# Altium schematic sheet Khoi_Sensor.SchDoc
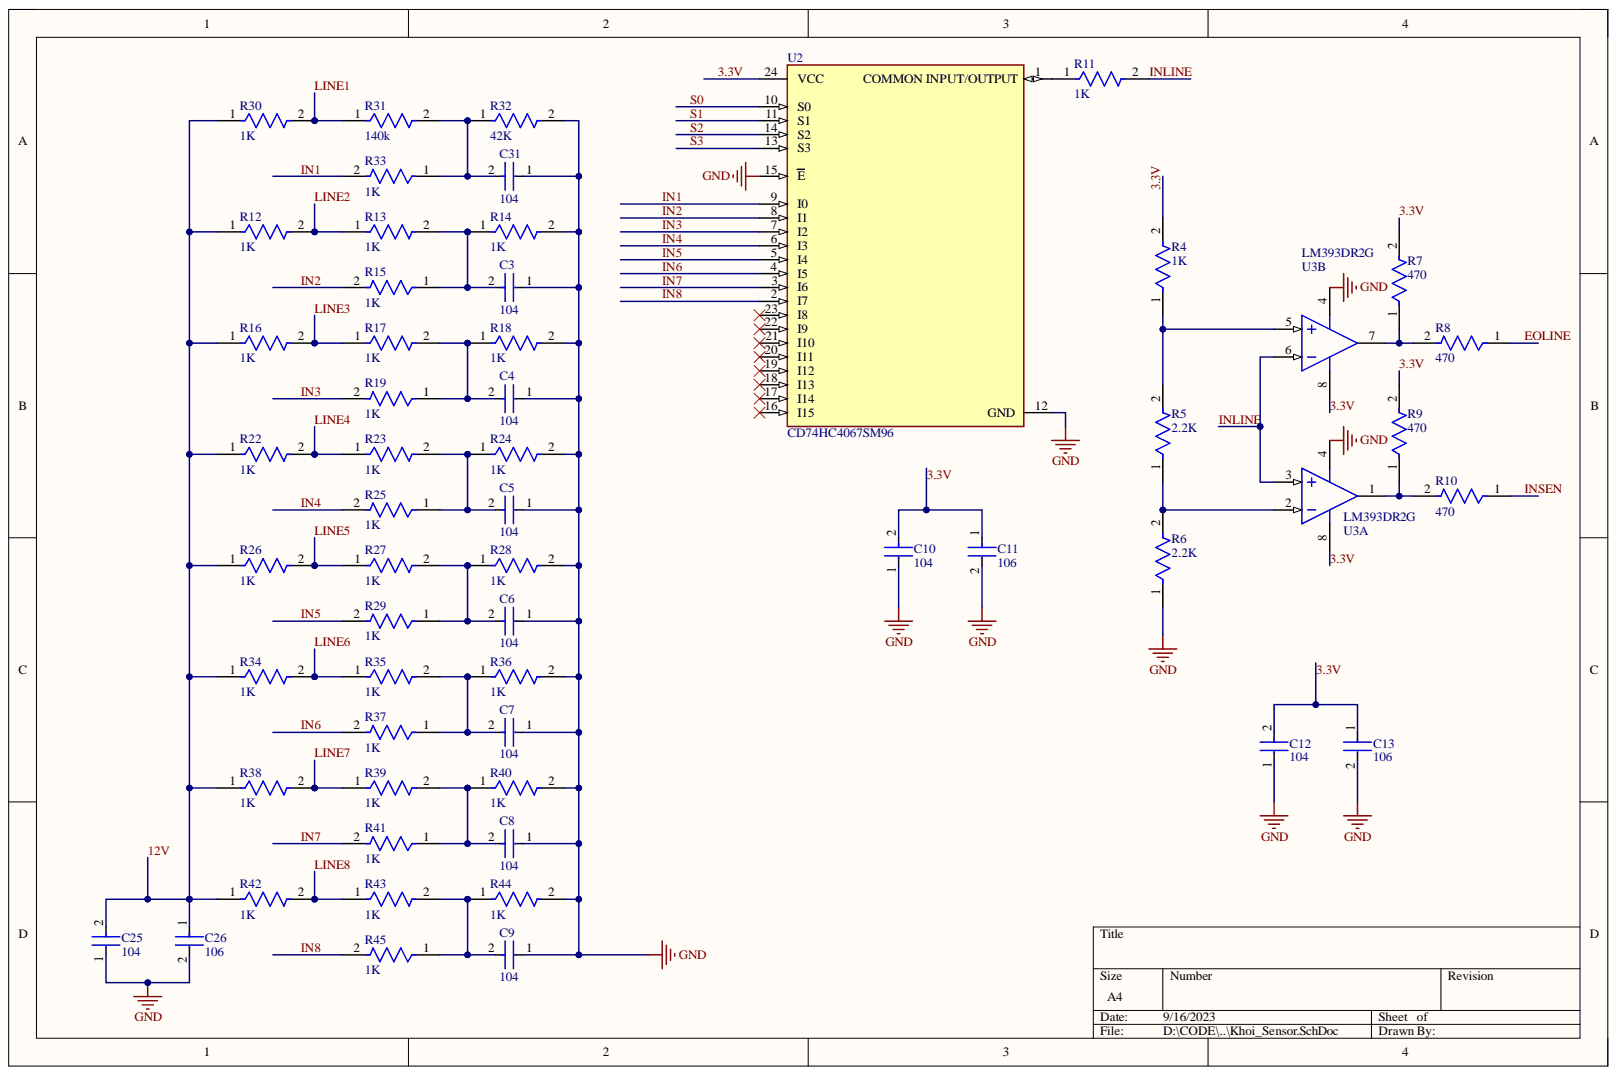
[diagram p0: Khoi_Sensor.SchDoc]

## Parts
C3  104  [FP-GRM15-MFG]
C4  104  [FP-GRM15-MFG]
C5  104  [FP-GRM15-MFG]
C6  104  [FP-GRM15-MFG]
C7  104  [FP-GRM15-MFG]
C8  104  [FP-GRM15-MFG]
C9  104  [FP-GRM15-MFG]
C10  104  [FP-GRM15-MFG]
C11  106  [FP-GRM188-0_1-e0_2_0_55-IPC_A]
C12  104  [FP-GRM15-MFG]
C13  106  [FP-GRM188-0_1-e0_2_0_55-IPC_A]
C25  104  [FP-GRM15-MFG]
C26  106  [FP-GRM188-0_1-e0_2_0_55-IPC_A]
C31  104  [FP-GRM15-MFG]
R4  1K  [FP-ERJ2RK-MFG]
R5  2.2K  [FP-ERJ2RK-MFG]
R6  2.2K  [FP-ERJ2RK-MFG]
R7  470  [FP-ERJ2RK-MFG]
R8  470  [FP-ERJ2RK-MFG]
R9  470  [FP-ERJ2RK-MFG]
R10  470  [FP-ERJ2RK-MFG]
R11  1K  [FP-ERJ2RK-MFG]
R12  1K  [FP-ERJ2RK-MFG]
R13  1K  [FP-ERJ2RK-MFG]
R14  1K  [FP-ERJ2RK-MFG]
R15  1K  [FP-ERJ2RK-MFG]
R16  1K  [FP-ERJ2RK-MFG]
R17  1K  [FP-ERJ2RK-MFG]
R18  1K  [FP-ERJ2RK-MFG]
R19  1K  [FP-ERJ2RK-MFG]
R22  1K  [FP-ERJ2RK-MFG]
R23  1K  [FP-ERJ2RK-MFG]
R24  1K  [FP-ERJ2RK-MFG]
R25  1K  [FP-ERJ2RK-MFG]
R26  1K  [FP-ERJ2RK-MFG]
R27  1K  [FP-ERJ2RK-MFG]
R28  1K  [FP-ERJ2RK-MFG]
R29  1K  [FP-ERJ2RK-MFG]
R30  1K  [FP-ERJ2RK-MFG]
R31  140k  [FP-ERJ2RK-MFG]
R32  42K  [FP-ERJ2RK-MFG]
R33  1K  [FP-ERJ2RK-MFG]
R34  1K  [FP-ERJ2RK-MFG]
R35  1K  [FP-ERJ2RK-MFG]
R36  1K  [FP-ERJ2RK-MFG]
R37  1K  [FP-ERJ2RK-MFG]
R38  1K  [FP-ERJ2RK-MFG]
R39  1K  [FP-ERJ2RK-MFG]
R40  1K  [FP-ERJ2RK-MFG]
R41  1K  [FP-ERJ2RK-MFG]
R42  1K  [FP-ERJ2RK-MFG]
R43  1K  [FP-ERJ2RK-MFG]
R44  1K  [FP-ERJ2RK-MFG]
R45  1K  [FP-ERJ2RK-MFG]
U2  CD74HC4067SM96  [FP-DB0024A-IPC_C]
U3  LM393DR2G  [ONSC-SOIC-8-751-07_V]  (parts A,B)

## Nets
GND: C3.1 C4.1 C5.1 C6.1 C7.1 C8.1 C9.1 C10.1 C11.2 C12.1 C13.2 C25.1 C26.2 C31.1 R6.1 R14.2 R18.2 R24.2 R28.2 R32.2 R36.2 R40.2 R44.2 U2.12(GND) U2.15(E) U3.4
3.3V: C10.2 C11.1 C12.2 C13.1 R4.2 R7.2 R9.2 U2.24(VCC) U3.8
12V: C25.2 C26.1 R12.1 R16.1 R22.1 R26.1 R30.1 R34.1 R38.1 R42.1
EOLINE: R8.1
IN1: R33.2 U2.9(I0)
IN2: R15.2 U2.8(I1)
IN3: R19.2 U2.7(I2)
IN4: R25.2 U2.6(I3)
IN5: R29.2 U2.5(I4)
IN6: R37.2 U2.4(I5)
IN7: R41.2 U2.3(I6)
IN8: R45.2 U2.2(I7)
INLINE: R11.2 U3.3 U3.6
INSEN: R10.1
LINE1: R30.2 R31.1
LINE2: R12.2 R13.1
LINE3: R16.2 R17.1
LINE4: R22.2 R23.1
LINE5: R26.2 R27.1
LINE6: R34.2 R35.1
LINE7: R38.2 R39.1
LINE8: R42.2 R43.1
S0: U2.10(S0)
S1: U2.11(S1)
S2: U2.14(S2)
S3: U2.13(S3)
NetC3_2: C3.2 R13.2 R14.1 R15.1
NetC4_2: C4.2 R17.2 R18.1 R19.1
NetC5_2: C5.2 R23.2 R24.1 R25.1
NetC6_2: C6.2 R27.2 R28.1 R29.1
NetC7_2: C7.2 R35.2 R36.1 R37.1
NetC8_2: C8.2 R39.2 R40.1 R41.1
NetC9_2: C9.2 R43.2 R44.1 R45.1
NetC31_2: C31.2 R31.2 R32.1 R33.1
NetR4_1: R4.1 R5.2 U3.5
NetR5_1: R5.1 R6.2 U3.2
NetR7_1: R7.1 R8.2 U3.7
NetR9_1: R9.1 R10.2 U3.1
NetR11_1: R11.1 U2.1

Not connected: U2 16(I15) 17(I14) 18(I13) 19(I12) 20(I11) 21(I10) 22(I9) 23(I8)

## Library data of the parts
C3-C10 C12 C25 C31 = CMP-2100-03641-2: CAP CER 0.1UF 16V X7R 0402; Manufacturer: Murata; Manufacturer Part Number: GRM155R71C104KA88D; Capacitance: 0.10μF; Material: Ceramic; Standoff Height: 0mm; Tolerance: 10%; Value: 0.10μF; Voltage Rating: 16Vdc; Category: Cap; Component Kind: Standard; ComponentLink2Description: Datasheet; ComponentLink2URL: https://search.murata.co.jp/Ceramy/image/img/A01X/G101/ENG/GRM155R71H471KA01-01A.pdf; Device Class L1: Passive Components; Device Class L2: Capacitors; Device Class L3: Ceramic Capacitors; Digikey Description: CAP CER 0.1UF 16V X7R 0402; Height: 0.55mm; Ipc Land Pattern Name: CAPC10050X219; Lead Free: yes; Library Name: Altium Content Vault; Mouser Description: 0402 0.1 uF 16V ±10 % Tolerance X7R SMT Multilayer Ceramic Capacitor; Octopart: https://octopart.com/grm155r71c104ka88d-murata-196880; Package: GRM15; RoHS: TRUE; Temperature: -55°C to +125°C; Temperature Characteristic: X7R; Temperature Range High: +125°C; Temperature Range Low: -55°C
C11 C13 C26 = CMP-2006-02792-2: Chip Multilayer Ceramic Capacitors for General Purpose, 0603, 10uF, X5R, 15%, 20%, 6.3V; Capacitance: 10uF; Case Code (Imperial): 0603; Case Code (Metric): 1608; Case/Package: 0603; Component Kind: Standard; Depth: 0.8mm; Dielectric: X5R; Height: 0.8mm; Lead Free: Lead Free; Length: 1.6mm; Library Name: Altium Content Vault; Material: Ceramic; Max Operating Temperature: 85°C; Min Operating Temperature: -55°C; Mount: Surface Mount; Package Quantity: 4000; Packaging: Tape and Reel; Radiation Hardening: No; REACH SVHC: No SVHC; Termination: SMD/SMT; Thickness: 0.035inch; Tolerance: 20%; Voltage: 6.3V; Voltage Rating: 6.3V; Voltage Rating (DC): 6.3V; Width: 0.031inch
R4-R19 R22-R45 = CMP-2002-00608-4: RES SMD 100K OHM 1% 1/10W 0402; Max Power Dissipation: 100mW; Resistance: 100kR; Temperature Coefficient: 100ppm/°C; Voltage Rating: 50V; Case Code (Imperial): 0402; Case Code (Metric): 1005; Case/Package: 0402; Component Kind: Standard; Composition: Thick Film; Contact Plating: Tin; Depth: 0.5mm; Features: Automotive AEC-Q200; Height: 0.35mm; Lead Free: Lead Free; Length: 1mm; Library Name: Altium Content Vault; Max Operating Temperature: 155°C; Military Standard: Not; Min Operating Temperature: -55°C; Mount: Surface Mount; Number of Pins: 2; Number of Terminations: 2; Package Quantity: 1; Packaging: Tape and Reel; Power Rating: 100mW; Radiation Hardening: No; REACH SVHC: No SVHC; Termination: SMD/SMT; Tolerance: 1%; Voltage Rating (DC): 50V; Width: 0.5mm
U2 = CMP-04892-000038-1: IC MUX/DEMUX 1X16 24SSOP; Bandwidth: 89MHz; High Level Output Current: 25mA; Logic Function: Multiplexer; Max Supply Current: 8uA; Max Supply Voltage: 6V; Min Supply Voltage: 2V; Number of Bits: 16; Number of Channels: 1; On-State Resistance: 160R; Polarity: Non-Inverting; Propagation Delay: 75ns; Supply Type: Single; Turn-Off Delay Time: 290ns; Turn-On Delay Time: 300ns; Case/Package: SSOP; Component Kind: Standard; Contact Plating: Gold; Height: 1.95mm; Lead Free: Lead Free; Length: 8.2mm; Library Name: Altium Content Vault; Low Level Output Current: 25mA; Max Operating Temperature: 125°C; Max Output Current: 25mA; Min Operating Temperature: -55°C; Mount: Surface Mount; Number of Circuits: 16; Number of Inputs: 16; Number of Outputs: 1; Number of Pins: 24; Operating Supply Current: 8uA; Package Quantity: 2000; Packaging: Tape and Reel; Radiation Hardening: No; REACH SVHC: No SVHC; Series: 74HC; Termination: SMD/SMT; Weight: 0.006081oz; Width: 5.3mm
U3 = CMP-1305-00048-1: Low Offset Voltage Dual Comparators, 2 to 36 V, 0 to 70 degC, 8-Pin SOIC, Pb-Free, Tape and Reel; Manufacturer: On Semiconductor; ICC Typ (mA): 0.4; IO Typ (mA): 16; VCC Max (V): 36; VCC Min (V): 2; Component Kind: Standard; ComponentLink1Description: Manufacturer URL; ComponentLink1URL: http://www.onsemi.com/; ComponentLink2Description: Datasheet; ComponentLink2URL: http://www.onsemi.com/pub_link/Collateral/LM393-D.PDF; ComponentLink3Description: Package Specification; ComponentLink3URL: http://www.onsemi.com/pub_link/Collateral/751-07.PDF; DatasheetVersion: Rev. 25; Library Name: Altium Content Vault; Mounting Technology: Surface Mount; PackageDescription: 8-Pin Small Outline Integrated Circuit, Body 4.9 x 3.9 mm, Pitch 1.27 mm; PackageReference: SOIC-8-751-07; PackageVersion: Rev. AK, 02/2011; Packing: Tape and Reel; PartNumber: LM393DR2G; RoHS: TRUE
